# Altium schematic sheet Schematic.schDoc(Schematic)
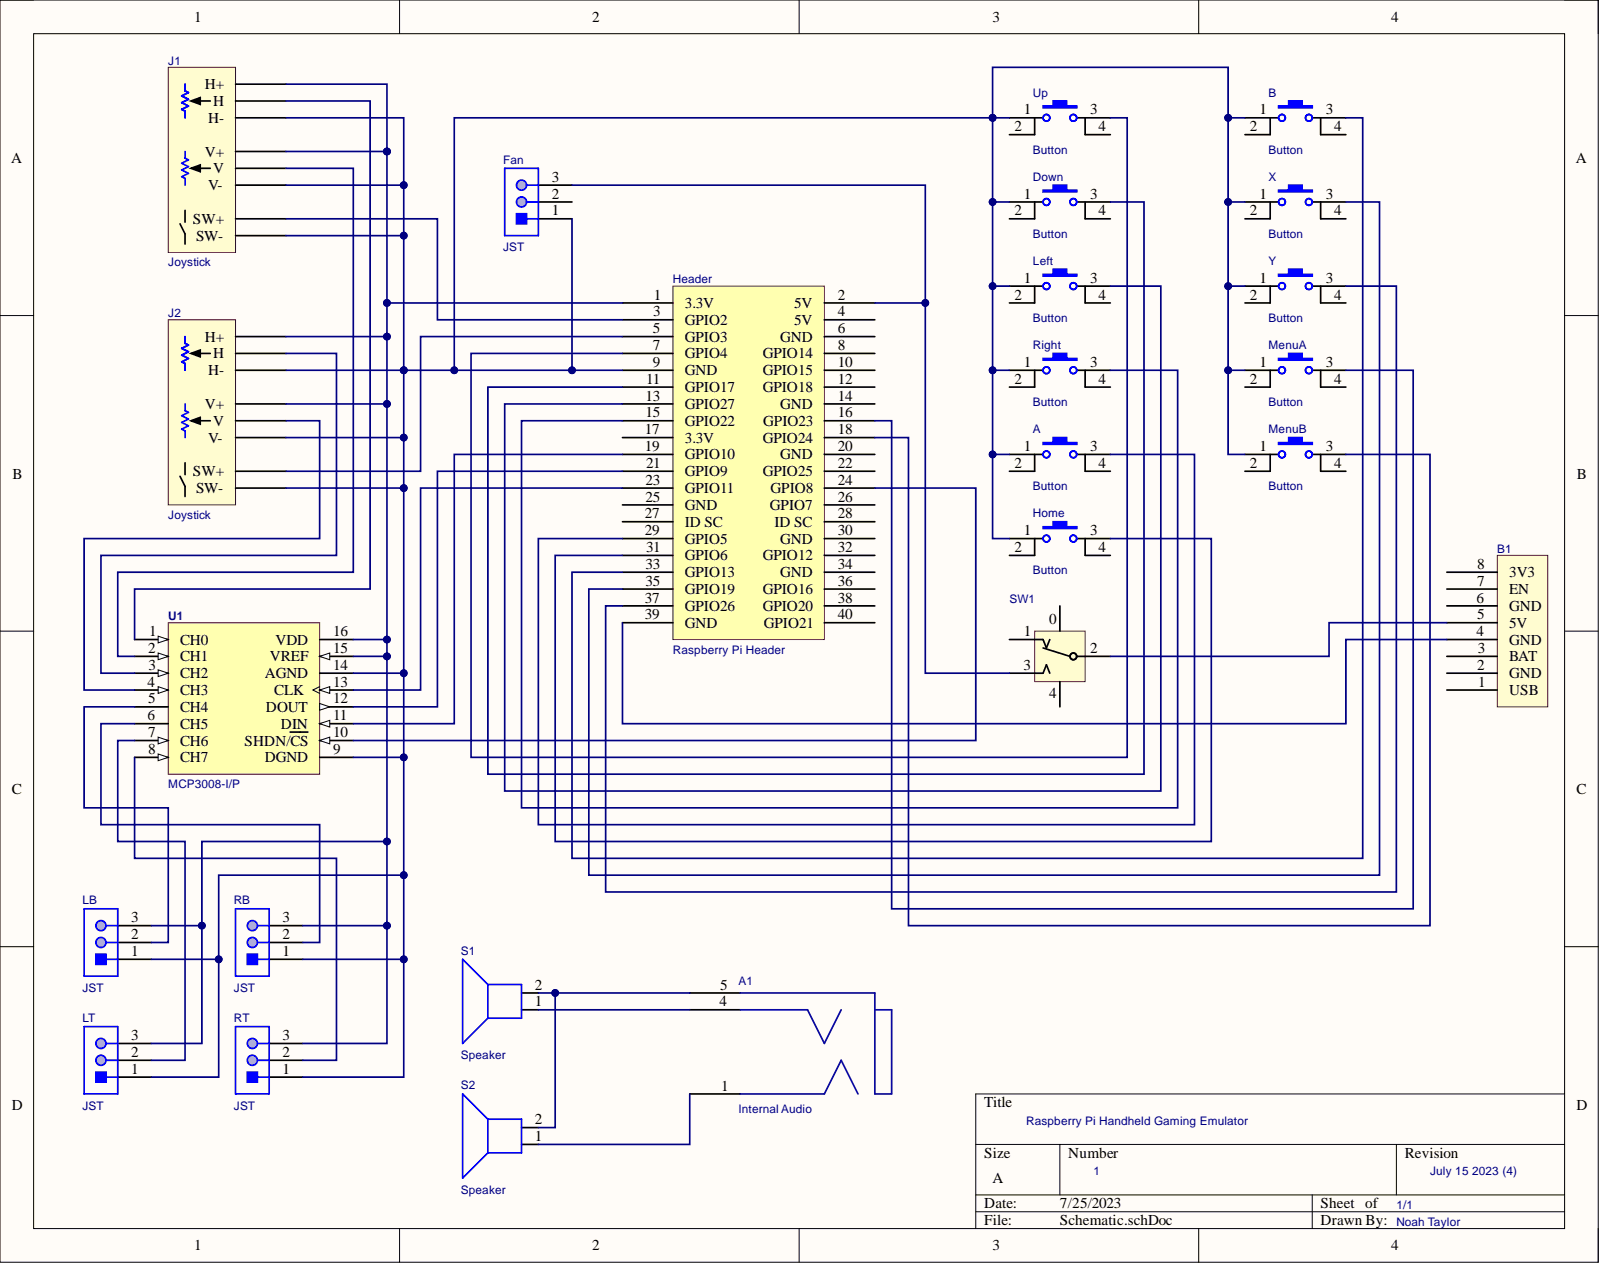
[diagram p0: Schematic.schDoc]

## Parts
A  Button
A1  Internal Audio
B  Button
B1  (no value)
Down  Button
Fan  JST
Header  Raspberry Pi Header  [PCB-vr2qmacvlj9olz112b3a-1]
Home  Button
J1  Joystick  [PCB-lkedppk7goe399qnkd0w-3]
J2  Joystick  [PCB-lkedppk7goe399qnkd0w-3]
LB  JST
Left  Button
LT  JST
MenuA  Button
MenuB  Button
RB  JST
Right  Button
RT  JST
S1  Speaker  [PCB-h6znsx2i7s8udb82qvyi-1]
S2  Speaker  [PCB-h6znsx2i7s8udb82qvyi-1]
SW1  (no value)  [PCB-magbxwz3kn5v7khtm4jp-1]
U1  MCP3008-I/P
Up  Button
X  Button
Y  Button

## Nets
NetA1_1: A1.1 S2.1
NetA1_4: A1.4 S1.1
NetA1_5: A1.5 S1.2 S2.2
NetA_1: A.1 B.1 Down.1 Fan.1 Header.9(GND) Home.1 J1.H3(H-) J1.SW2(SW-) J1.V3(V-) J2.H3(H-) J2.SW2(SW-) J2.V3(V-) LB.1 Left.1 LT.1 MenuA.1 MenuB.1 RB.1 Right.1 RT.1 U1.9(DGND) U1.14(AGND) Up.1 X.1 Y.1
NetA_3: A.3 Header.29(GPIO5)
NetB1_4: B1.4(GND) Header.39(GND)
NetB1_5: B1.5(5V) SW1.2
NetB_3: B.3 Header.33(GPIO13)
NetDown_3: Down.3 Header.11(GPIO17)
NetFan_3: Fan.3 Header.2(5V) SW1.3
NetHeader_1: Header.1(3.3V) J1.H1(H+) J1.V1(V+) J2.H1(H+) J2.V1(V+) LB.3 LT.3 RB.3 RT.3 U1.15(VREF) U1.16(VDD)
NetHeader_3: Header.3(GPIO2) J1.SW1(SW+)
NetHeader_5: Header.5(GPIO3) J2.SW1(SW+)
NetHeader_7: Header.7(GPIO4) Up.3
NetHeader_13: Header.13(GPIO27) Left.3
NetHeader_15: Header.15(GPIO22) Right.3
NetHeader_16: Header.16(GPIO23) MenuA.3
NetHeader_18: Header.18(GPIO24) MenuB.3
NetHeader_19: Header.19(GPIO10) U1.11(DIN)
NetHeader_21: Header.21(GPIO9) U1.12(DOUT)
NetHeader_23: Header.23(GPIO11) U1.13(CLK)
NetHeader_24: Header.24(GPIO8) U1.10(SHDN/CS)
NetHeader_31: Header.31(GPIO6) Home.3
NetHeader_35: Header.35(GPIO19) X.3
NetHeader_37: Header.37(GPIO26) Y.3
NetJ1_H2: J1.H2(H) U1.1(CH0)
NetJ1_V2: J1.V2(V) U1.2(CH1)
NetJ2_H2: J2.H2(H) U1.3(CH2)
NetJ2_V2: J2.V2(V) U1.4(CH3)
NetLB_2: LB.2 U1.5(CH4)
NetLT_2: LT.2 U1.7(CH6)
NetRB_2: RB.2 U1.6(CH5)
NetRT_2: RT.2 U1.8(CH7)

Not connected: A 2 4; B 2 4; B1 1(USB) 2(GND) 3(BAT) 6(GND) 7(EN) 8(3V3); Down 2 4; Fan 2; Header 4(5V) 6(GND) 8(GPIO14) 10(GPIO15) 12(GPIO18) 14(GND) 17(3.3V) 20(GND) 22(GPIO25) 25(GND) 26(GPIO7) 27(ID_SC) 28(ID_SC) 30(GND) 32(GPIO12) 34(GND) 36(GPIO16) 38(GPIO20) 40(GPIO21); Home 2 4; Left 2 4; MenuA 2 4; MenuB 2 4; Right 2 4; SW1 0 1 4; Up 2 4; X 2 4; Y 2 4

## Library data of the parts
A B Down Home Left MenuA MenuB Right Up X Y = CMP-34bcffd9c077eb7b-11: Switch Tactile OFF (ON) SPST Round Button Gull Wing 0.05A 24VDC 1VA 9.18N SMD T/R; Manufacturer Part Number: 2-1437565-9; Lead-Free Status: Lead Free; Component Kind: Standard; Library Name: My Personal Space; Lifecycle Status: Not Listed by Manufacturer; Octopart URL: http://octopart.com/2-1437565-9-te+connectivity-40882605; OctopartID: 34bcffd9c077eb7b; RoHS: Compliant
A1 = CMP-329482-6: CONN JACK MONO 3.5MM SMD; Manufacturer Part Number: 35RASMT2BHNTRX; Lead-Free Status: Lead Free; Component Kind: Standard; Library Name: My Personal Space; Octopart Url: https://octopart.com/35rasmt2bhntrx-switchcraft-604034; OctopartID: 3284fb872060ed43; RoHS: Compliant
B1 = CMP-bf4e707bdb856089-8: Lipo Rider Plus (Charger/Booster) - 5V/2.4A USB Type C; Manufacturer Part Number: 106990290; Component Kind: Standard; Library Name: My Personal Space; Octopart Url: https://octopart.com/106990290-seeed+studio-104808877; OctopartID: bf4e707bdb856089
Fan LB LT RB RT = CMP-642977-4: Male Header, Pitch 3.96 mm, 1 x 3 Position, Height 10.9 mm, Tail Length 3.7 mm, -25 to 85 degC, RoHS, Bulk; Manufacturer Part Number: B3P-VH(LF)(SN); Current Rating: 10.0 A; Insulation Resistance: 1.00 GΩ; Lead-Free Status: Lead Free; Number of Contacts: 3; Pin Pitch: 3.96 mm; Voltage Rating (AC): 250 V; Component Kind: Standard; Contact Material: Brass; Contact Plating: Tin; DatasheetVersion: May-16; Gender: Male; Housing Color: Natural; Housing Material: Nylon; Library Name: My Personal Space; Mounting Style: Through Hole, Vertical; Number of Positions: 3; Number of Rows: 1; Octopart Url: http://octopart.com/b3p-vh%28lf%29%28sn%29-jst-248804; OctopartID: 02bbcbb2ec39dd7c; PackageDescription: Male Header, Pitch 3.96 mm, 1 x 3 Position, Height 10.9 mm, Tail Length 3.7 mm; PackageReference: B3P-VH(LF)(SN); Packaging: Bulk; REACH SVHC Compliance: No SVHC; RoHS: Compliant; Seller Landing  Url: https://octopart-clicks.com/click/track?country=US&ak=8157f166&sig=0ecde6d&sid=459&ppid=248804&vpid=1897781&ct=offers; Size-Height: 9.40 mm; Size-Length: 11.8 mm; Size-Width: 8.50 mm
Header = CMP-1402de3e1451cbc5-17: Adafruit 2222; Manufacturer Part Number: 2222; Lead-Free Status: Lead Free; Number of Contacts: 2; Component Kind: Standard; Contact Material: Brass; Contact Plating: Nickel; Library Name: My Personal Space; Material: Aluminum; Mounting Style: Through Hole, PC Board, Lug, PCB; Octopart URL: https://octopart.com/2222-adafruit+industries-53880117; OctopartID: 1402de3e1451cbc5; Packaging: Bulk; REACH SVHC Compliance: No SVHC; RoHS: Compliant; Termination Style: Solder Lug
J1 J2 = CMP-d3091e8e8ab50cce-11: Thumb Joystick, 2-Axis Analog (10K Resistive) Output; Component Kind: Standard; Library Name: My Personal Space; OctopartID: d3091e8e8ab50cce
S1 S2 = CMP-b4a27daacd586b65-1: CDMG Series 8 Ohm 600 Hz 82 dB 300 mW Ø 15 x 2.8 mm Magnetic Speaker; Manufacturer Part Number: CDMG16008-03; Frequency Range: 600Hz ~ 20kHz; Lead-Free Status: Lead Free; Material - Cone: PET; Material - Magnet: Nd-Fe-B; Power - Max: 500 mW; Power - Rating: 300 mW; Sound Pressure Level @ 0.3 W, 10cm: 82 dB; Sound Pressure Level @ 1 W, 1 m: 69 dB; Component Kind: Standard; Housing Material: Plastic; Library Name: My Personal Space; Lifecycle Status: Active; Mounting Style: Surface Mount; Octopart URL: http://octopart.com/cdmg16008-03-cui-154780; OctopartID: b4a27daacd586b65; RoHS: Compliant; Weight: 1.50 g
SW1 = CMP-0fe8c453f395d02f-7: Switch Slide ON None ON SPDT Side Slide 0.2A 30VDC 10000Cycle PC Pins Bracket Mount/Through Hole; Manufacturer Part Number: 1825232-1; ELV Compliant: Compliant; Component Kind: Standard; Contact Material: Copper Alloy; Contact Plating: Silver; Contacts Type: SPDT; Flammability Rating: UL 94 HB; Library Name: My Personal Space; Lifecycle Status: Active; Mounting Style: Through Hole; Octopart URL: https://octopart.com/1825232-1-te+connectivity-45209882; OctopartID: d6821d392afe0945; Packaging: Tray; RoHS: Compliant
U1 = CMP-1029167-15: 8 Channel SPI Serial Interface 2.7 V 10-Bit A/D Converter Through Hole - PDIP-16; Digital Logic Level: SAR; Lead-Free Status: Lead Free; Number of Bits: 10; Number of Channels: 8; Sample Rate: 200000.0 Hz; Supply Current: 0.000425 A; Case/Package: DIP; Component Kind: Standard; Library Name: My Personal Space; Lifecycle Status: Active; Manufacturer Part Number: MCP3008-I/P; Mounting Style: Through Hole; Number of Pins: 16; Octopart Url: http://octopart.com/mcp3008-i%2Fp-microchip-407339; OctopartID: f3bd3ab1e1f02c7a; REACH SVHC Compliance: No SVHC; RoHS: Compliant; Seller Landing  Url: https://octopart-clicks.com/click/track?country=US&ak=8157f166&sig=024ed89&sid=2402&ppid=407339&vpid=2919170&ct=offers
